# Altium schematic sheet circuit.SchDoc
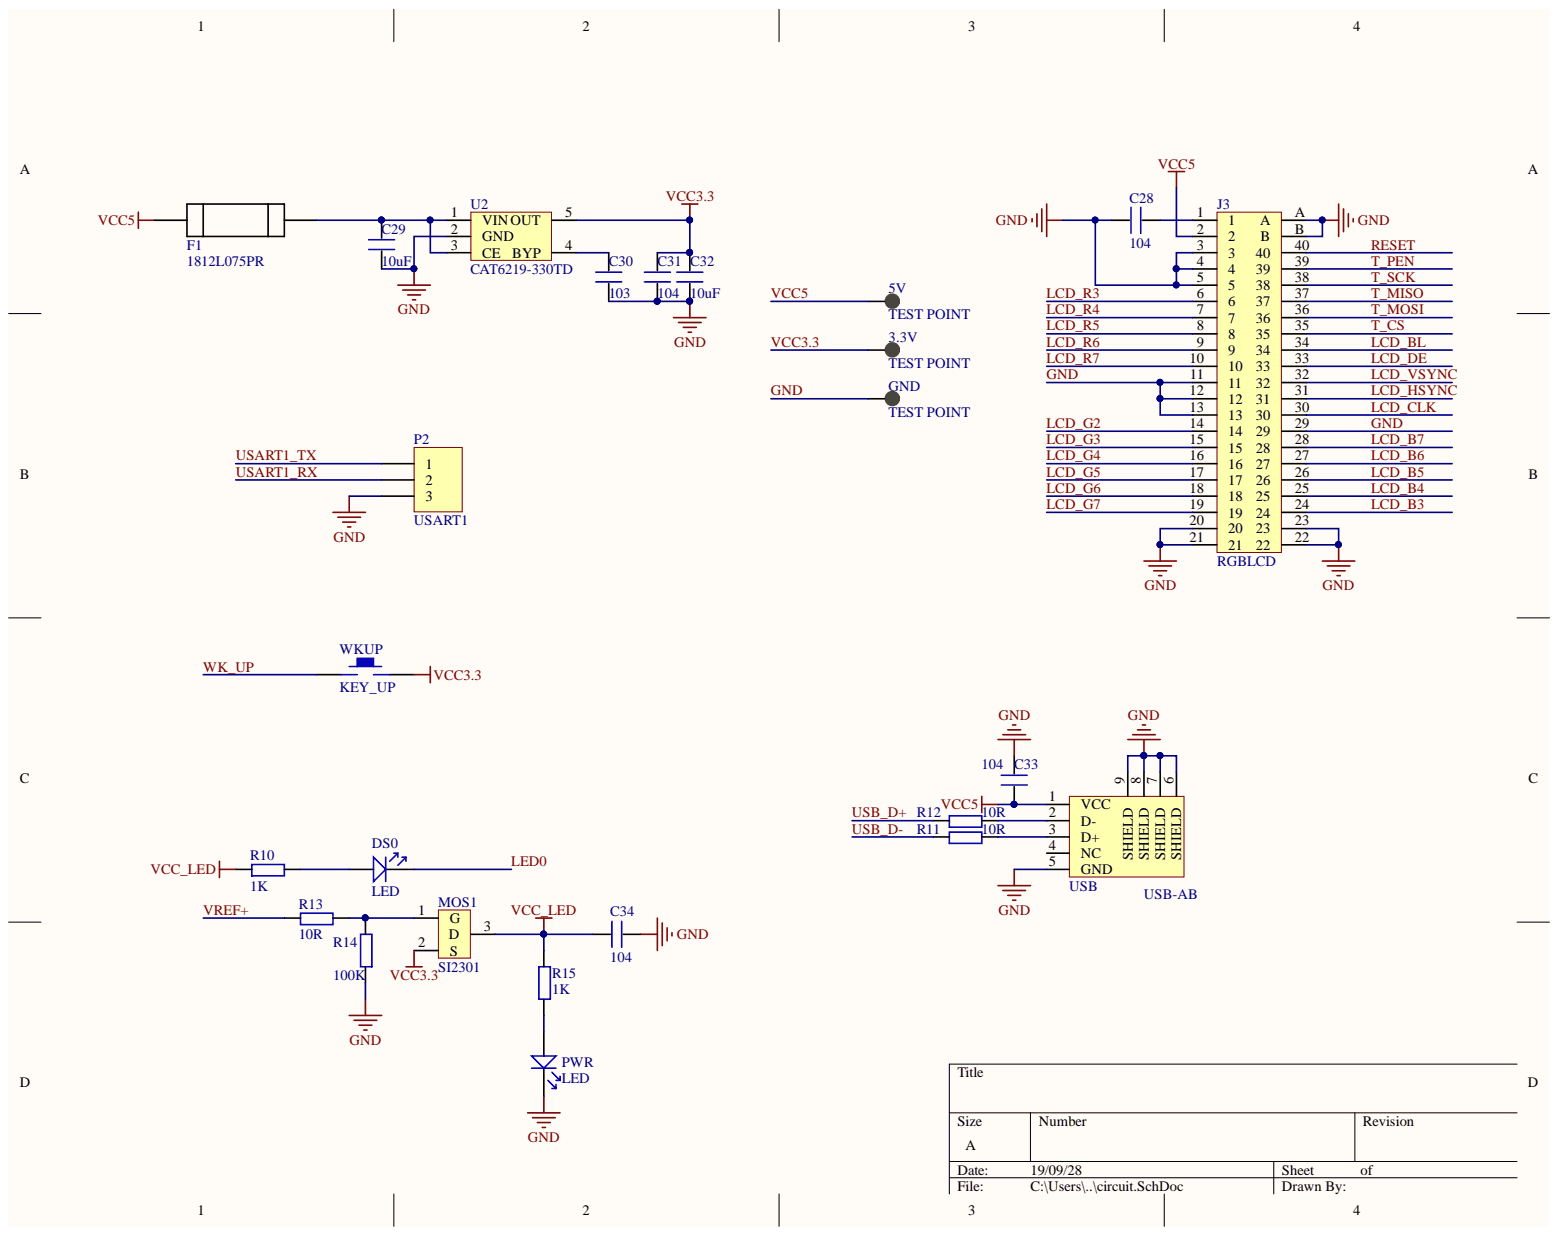
[diagram p0: circuit.SchDoc]

## Parts
3.3V  TEST POINT  [TP-1.5]
5V  TEST POINT  [TP-1.5]
C28  104  [0805]
C29  10uF  [0805]
C30  103  [0805]
C31  104  [0805]
C32  10uF  [0805]
C33  104  [0805]
C34  104  [0805]
DS0  LED  [SMDLED-0603]
F1  1812L075PR  [*1812]
GND  TEST POINT  [TP-1.5]
J3  RGBLCD  [FPC-TOP40]
MOS1  SI2301  [SO-G3/C2.5]
P2  USART1  [HDR1X3]
PWR  LED  [SMDLED-0603]
R10  1K  [0805]
R11  10R  [0805]
R12  10R  [0805]
R13  10R  [0805]
R14  100K  [0805]
R15  1K  [0805]
U2  CAT6219-330TD  [SOT-23-5]
USB  USB-AB  [USB_AB - 5S - B]
WKUP  KEY_UP  [KEY_SOP3625]

## Nets
VCC_LED: C34.1 MOS1.3 R10.1 R15.2
GND: C28.1 C29.1 C30.1 C31.1 C32.1 C33.2 C34.2 GND.1 J3.3 J3.4 J3.5 J3.11 J3.12 J3.13 J3.20 J3.21 J3.22 J3.23 J3.29 J3.A J3.B P2.3 PWR.2 R14.1 U2.2(GND) USB.5(GND) USB.6(SHIELD) USB.7(SHIELD) USB.8(SHIELD) USB.9(SHIELD)
LCD_B3: J3.24
LCD_B4: J3.25
LCD_B5: J3.26
LCD_B6: J3.27
LCD_B7: J3.28
LCD_BL: J3.34
LCD_CLK: J3.30
LCD_DE: J3.33
LCD_G2: J3.14
LCD_G3: J3.15
LCD_G4: J3.16
LCD_G5: J3.17
LCD_G6: J3.18
LCD_G7: J3.19
LCD_HSYNC: J3.31
LCD_R3: J3.6
LCD_R4: J3.7
LCD_R5: J3.8
LCD_R6: J3.9
LCD_R7: J3.10
LCD_VSYNC: J3.32
LED0: DS0.2
RESET: J3.40
T_CS: J3.35
T_MISO: J3.37
T_MOSI: J3.36
T_PEN: J3.39
T_SCK: J3.38
USART1_RX: P2.2
USART1_TX: P2.1
USB_D+: R12.1
USB_D-: R11.1
VCC3.3: 3.3V.1 C31.2 C32.2 MOS1.2 U2.5(OUT) WKUP.2
VCC5: 5V.1 C33.1 F1.1 J3.2 USB.1(VCC)
VREF+: R13.1
WK_UP: WKUP.1
NetC28_2: C28.2 J3.1
NetC29_2: C29.2 F1.2 U2.1(VIN) U2.3(CE)
NetC30_2: C30.2 U2.4(BYP)
NetDS0_1: DS0.1 R10.2
NetMOS1_1: MOS1.1 R13.2 R14.2
NetPWR_1: PWR.1 R15.1
NetR11_2: R11.2 USB.3(D+)
NetR12_2: R12.2 USB.2(D-)

Not connected: USB 4(NC)

## Library data of the parts
3.3V 5V GND = TEST-POINT: 测试点; Component Kind: Standard; Library Name: STM32F767核心板封装库.IntLib
C28-C34 = C: Component Kind: Standard; Library Name: STM32F767核心板封装库.IntLib
F1 = 1812L075PR: PTC Resettable Fuse 0.75A_hold_ 1.5A_trip_ 13.2VDC 100A 0.8W 0.2s 0.1Ohm SMD Solder Pad 1812 T/R; MF: Littelfuse Inc.; Availability: Unavailable; Component Kind: Standard; Library Name: 1812L075PR.SchLib; MP: 1812L075PR; Package: 1812 Littelfuse; Price: None; SnapEDA_Link: https://www.snapeda.com/parts/1812L075PR/Littelfuse%20Inc./view-part/?ref=snap
J3 = FPC-40: FPC-40P-0.5mm; Component Kind: Standard; Library Name: STM32F767核心板封装库.IntLib
MOS1 = MOS-P: IRLML6401/SI2301; Component Kind: Standard; Library Name: STM32F767核心板封装库.IntLib
P2 = Header 3: Header, 3-Pin; Component Kind: Standard; LatestRevisionDate: 17-Jul-2002; LatestRevisionNote: Re-released for DXP Platform.; Library Name: STM32F767核心板封装库.IntLib; Signal Integrity: Connector; Publisher: Altium Limited
R10-R15 = R: Component Kind: Standard; Library Name: STM32F767核心板封装库.IntLib
U2 = CAT6219-330TD: 3.3V 500mA LDO; Component Kind: Standard; Library Name: STM32F767核心板封装库.IntLib
USB = USB-AB: MicroUSB; Component Kind: Standard; Library Name: STM32F767核心板封装库.IntLib
WKUP = KEY_M: Component Kind: Standard; Library Name: STM32F767核心板封装库.IntLib
DS0 PWR = LED: Component Kind: Standard; Library Name: STM32F767核心板封装库.IntLib
